# Altium schematic sheet FireFly.SchDoc(FireFly)
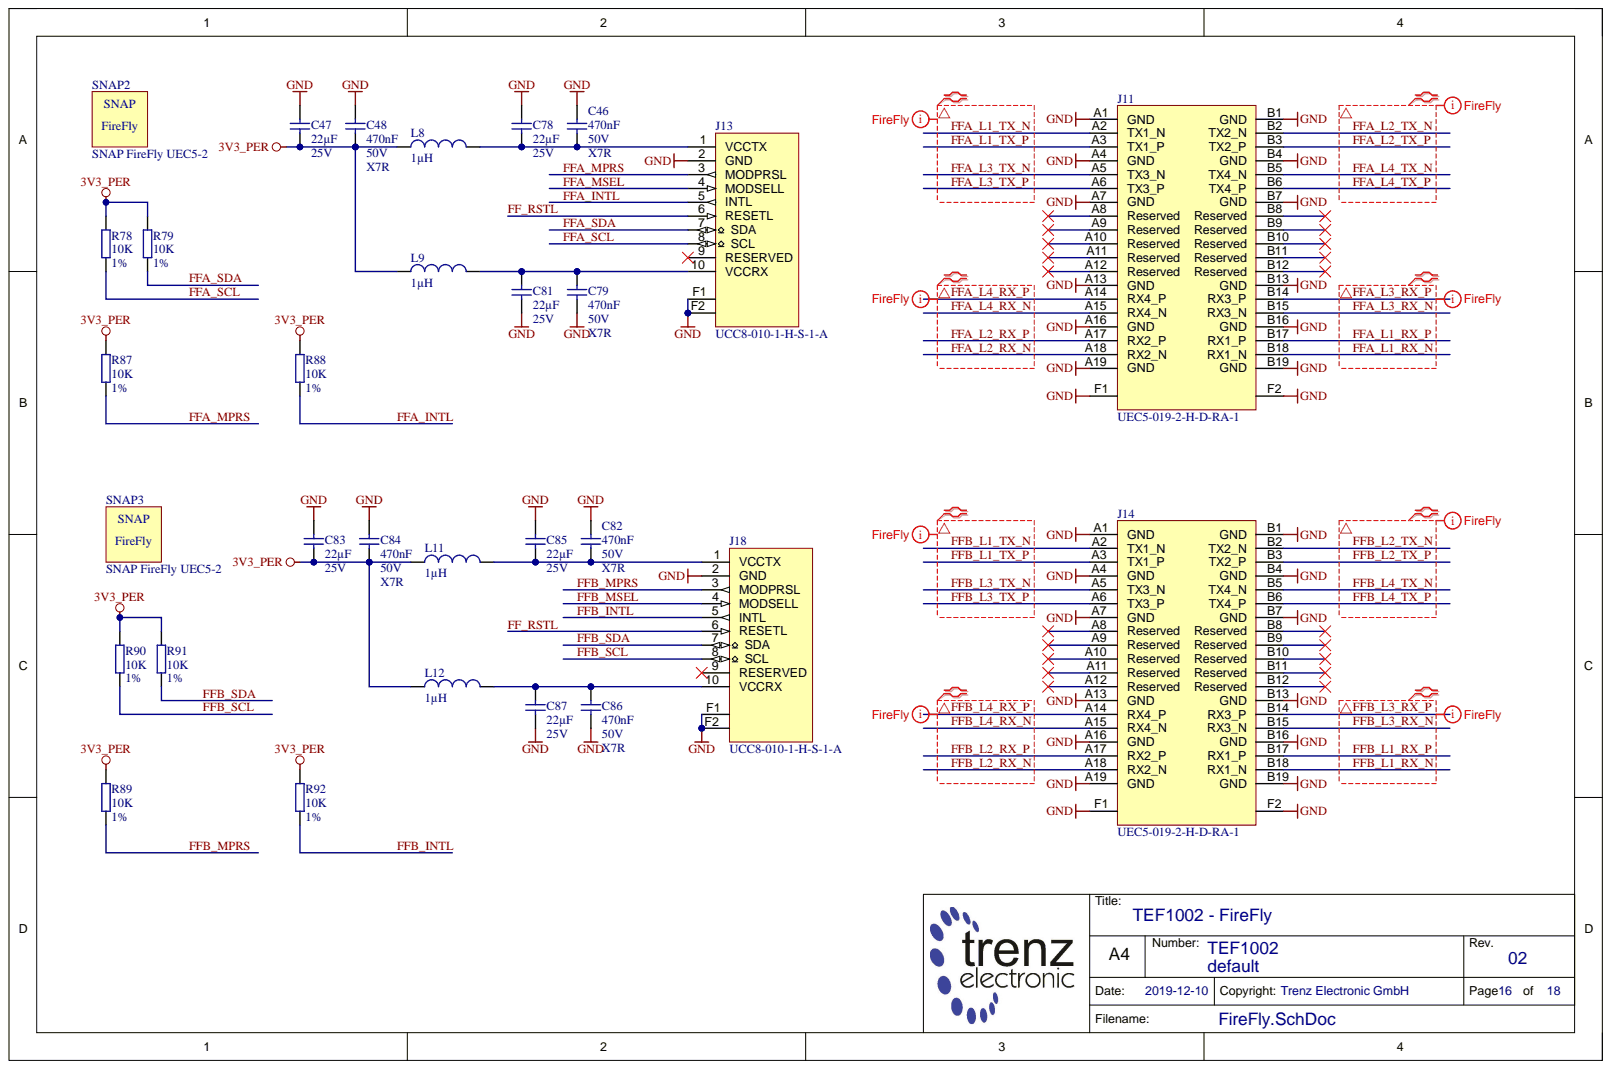
[diagram p0: FireFly.SchDoc]

## Parts
C46  470nF  Technology: X7R  Voltage: 50V  [CAPC1608X90N]
C47  22µF  Voltage: 25V  [CAPC3216X180N]
C48  470nF  Technology: X7R  Voltage: 50V  [CAPC1608X90N]
C78  22µF  Voltage: 25V  [CAPC3216X180N]
C79  470nF  Technology: X7R  Voltage: 50V  [CAPC1608X90N]
C81  22µF  Voltage: 25V  [CAPC3216X180N]
C82  470nF  Technology: X7R  Voltage: 50V  [CAPC1608X90N]
C83  22µF  Voltage: 25V  [CAPC3216X180N]
C84  470nF  Technology: X7R  Voltage: 50V  [CAPC1608X90N]
C85  22µF  Voltage: 25V  [CAPC3216X180N]
C86  470nF  Technology: X7R  Voltage: 50V  [CAPC1608X90N]
C87  22µF  Voltage: 25V  [CAPC3216X180N]
J11  UEC5-019-2-H-D-RA-1  [UEC5-019-2-X-D-RA-1]
J13  UCC8-010-1-H-S-1-A  [UCC8-010-1-X-S-1-A]
J14  UEC5-019-2-H-D-RA-1  [UEC5-019-2-X-D-RA-1]
J18  UCC8-010-1-H-S-1-A  [UCC8-010-1-X-S-1-A]
L8  1µH  [INDC2520X100N]
L9  1µH  [INDC2520X100N]
L11  1µH  [INDC2520X100N]
L12  1µH  [INDC2520X100N]
R78  10K  Tolerance: 1%  [RESC1005X40N]
R79  10K  Tolerance: 1%  [RESC1005X40N]
R87  10K  Tolerance: 1%  [RESC1005X40N]
R88  10K  Tolerance: 1%  [RESC1005X40N]
R89  10K  Tolerance: 1%  [RESC1005X40N]
R90  10K  Tolerance: 1%  [RESC1005X40N]
R91  10K  Tolerance: 1%  [RESC1005X40N]
R92  10K  Tolerance: 1%  [RESC1005X40N]
SNAP2  SNAP FireFly UEC5-2  [FireFly_Snap_UEC5-2-3]  (no BOM)
SNAP3  SNAP FireFly UEC5-2  [FireFly_Snap_UEC5-2-3]  (no BOM)

## Nets
3V3_PER: C47.2 C48.2 C83.2 C84.2 L8.1 L9.1 L11.1 L12.1 R78.1 R79.1 R87.1 R88.1 R89.1 R90.1 R91.1 R92.1
GND: C46.1 C47.1 C48.1 C78.2 C79.2 C81.1 C82.1 C83.1 C84.1 C85.2 C86.2 C87.1 J11.A1(GND) J11.A4(GND) J11.A7(GND) J11.A13(GND) J11.A16(GND) J11.A19(GND) J11.B1(GND) J11.B4(GND) J11.B7(GND) J11.B13(GND) J11.B16(GND) J11.B19(GND) J11.F1 J11.F2 J13.2(GND) J13.F1 J13.F2 J14.A1(GND) J14.A4(GND) J14.A7(GND) J14.A13(GND) J14.A16(GND) J14.A19(GND) J14.B1(GND) J14.B4(GND) J14.B7(GND) J14.B13(GND) J14.B16(GND) J14.B19(GND) J14.F1 J14.F2 J18.2(GND) J18.F1 J18.F2
FF_RSTL: J13.6(RESETL) J18.6(RESETL)
FFA_INTL: J13.5(INTL) R88.2
FFA_L1_RX_N: J11.B18(RX1_N)
FFA_L1_RX_P: J11.B17(RX1_P)
FFA_L1_TX_N: J11.A2(TX1_N)
FFA_L1_TX_P: J11.A3(TX1_P)
FFA_L2_RX_N: J11.A18(RX2_N)
FFA_L2_RX_P: J11.A17(RX2_P)
FFA_L2_TX_N: J11.B2(TX2_N)
FFA_L2_TX_P: J11.B3(TX2_P)
FFA_L3_RX_N: J11.B15(RX3_N)
FFA_L3_RX_P: J11.B14(RX3_P)
FFA_L3_TX_N: J11.A5(TX3_N)
FFA_L3_TX_P: J11.A6(TX3_P)
FFA_L4_RX_N: J11.A15(RX4_N)
FFA_L4_RX_P: J11.A14(RX4_P)
FFA_L4_TX_N: J11.B5(TX4_N)
FFA_L4_TX_P: J11.B6(TX4_P)
FFA_MPRS: J13.3(MODPRSL) R87.2
FFA_MSEL: J13.4(MODSELL)
FFA_SCL: J13.8(SCL) R78.2
FFA_SDA: J13.7(SDA) R79.2
FFB_INTL: J18.5(INTL) R92.2
FFB_L1_RX_N: J14.B18(RX1_N)
FFB_L1_RX_P: J14.B17(RX1_P)
FFB_L1_TX_N: J14.A2(TX1_N)
FFB_L1_TX_P: J14.A3(TX1_P)
FFB_L2_RX_N: J14.A18(RX2_N)
FFB_L2_RX_P: J14.A17(RX2_P)
FFB_L2_TX_N: J14.B2(TX2_N)
FFB_L2_TX_P: J14.B3(TX2_P)
FFB_L3_RX_N: J14.B15(RX3_N)
FFB_L3_RX_P: J14.B14(RX3_P)
FFB_L3_TX_N: J14.A5(TX3_N)
FFB_L3_TX_P: J14.A6(TX3_P)
FFB_L4_RX_N: J14.A15(RX4_N)
FFB_L4_RX_P: J14.A14(RX4_P)
FFB_L4_TX_N: J14.B5(TX4_N)
FFB_L4_TX_P: J14.B6(TX4_P)
FFB_MPRS: J18.3(MODPRSL) R89.2
FFB_MSEL: J18.4(MODSELL)
FFB_SCL: J18.8(SCL) R90.2
FFB_SDA: J18.7(SDA) R91.2
NetC46_2: C46.2 C78.1 J13.1(VCCTX) L8.2
NetC79_1: C79.1 C81.2 J13.10(VCCRX) L9.2
NetC82_2: C82.2 C85.1 J18.1(VCCTX) L11.2
NetC86_1: C86.1 C87.2 J18.10(VCCRX) L12.2

Not connected: J11 A8(Reserved) A9(Reserved) A10(Reserved) A11(Reserved) A12(Reserved) B8(Reserved) B9(Reserved) B10(Reserved) B11(Reserved) B12(Reserved); J13 9(RESERVED); J14 A8(Reserved) A9(Reserved) A10(Reserved) A11(Reserved) A12(Reserved) B8(Reserved) B9(Reserved) B10(Reserved) B11(Reserved) B12(Reserved); J18 9(RESERVED)

## Library data of the parts
C46 C48 C79 C82 C84 C86 = 25476: KON 470nF 50V X7R SMD  BF 1608 (0603); Database Table Name: C_1608_0603; Mixed: SMT; Preferred: Yes; Temperature-Range: -55/+125; Value: 470n; AN: 25476; Case: 1608 (0603); Component Kind: Standard; Library Name: TE.DbLib
C47 C78 C81 C83 C85 C87 = 24886: KON 22µF X5R 25V 10%  SMD BF 3216 (1206); Database Table Name: C_3216_1206; Mixed: SMT; Preferred: Yes; Temperature-Range: -55/+85; Value: 22u; AN: 24886; Case: 3216 (1206); Component Kind: Standard; Library Name: TE.DbLib; Technology: X5R; Tolerance: 10%
J11 J14 = 29263: Database Table Name: CON_IO; Mixed: SMT; AN: 29263; Case: UEC5-2; Component Kind: Standard; Description: UEC5-019-2-H-D-RA-1; H-ART: UEC5-019-2-H-D-RA-1; Library Name: TE.DbLib; HelpURL: B:\AD\adlib\datasheet\UEC5_UCC8
J13 J18 = 27354: Database Table Name: CON_IO; Mixed: SMT; AN: 27354; Case: UCC8; Component Kind: Standard; Description: UCC8-010-1-H-S-1-A; H-ART: UCC8-010-1-H-S-1-A; Library Name: TE.DbLib; HelpURL: B:\AD\adlib\datasheet\UEC5_UCC8
L8 L9 L11 L12 = 25265: IND SMD 1µH +-20% 0.055Ohm; Database Table Name: L_SMD; H-ART: 74479787210a; Mixed: SMT; Temperature-Range: -40/+85; Trenz-Stock: Yes; Value: 1u; Voltage: 1.7A; AN: 25265; Case: WE-PMI 2520(1008); Component Kind: Standard; Library Name: TE.DbLib; Technology: 0.055Ohm; HelpURL: B:\AD\adlib\datasheet\74479787210a
R78 R79 R87-R92 = 22750: WID SMD 10K 1% 0,063W BF 1005 (0402); Database Table Name: R_1005_0402; H-ART: RC0402FR-0710KL; Mixed: SMT; Preferred: Yes; AN: 22750; Case: 1005 (0402); Component Kind: Standard; Library Name: TE.DbLib; Technology: TK100; Value: 10K
SNAP2 SNAP3 = 29272: SNAP FireFly Socket System for UEC5-2; Database Table Name: BLOCKS; Mixed: SMT; AN: 29272; Library Name: TE.DbLib; HelpURL: B:\AD\adlib\datasheet\UEC5_UCC8
